# Altium schematic sheet Sheet1.SchDoc(Sheet1)
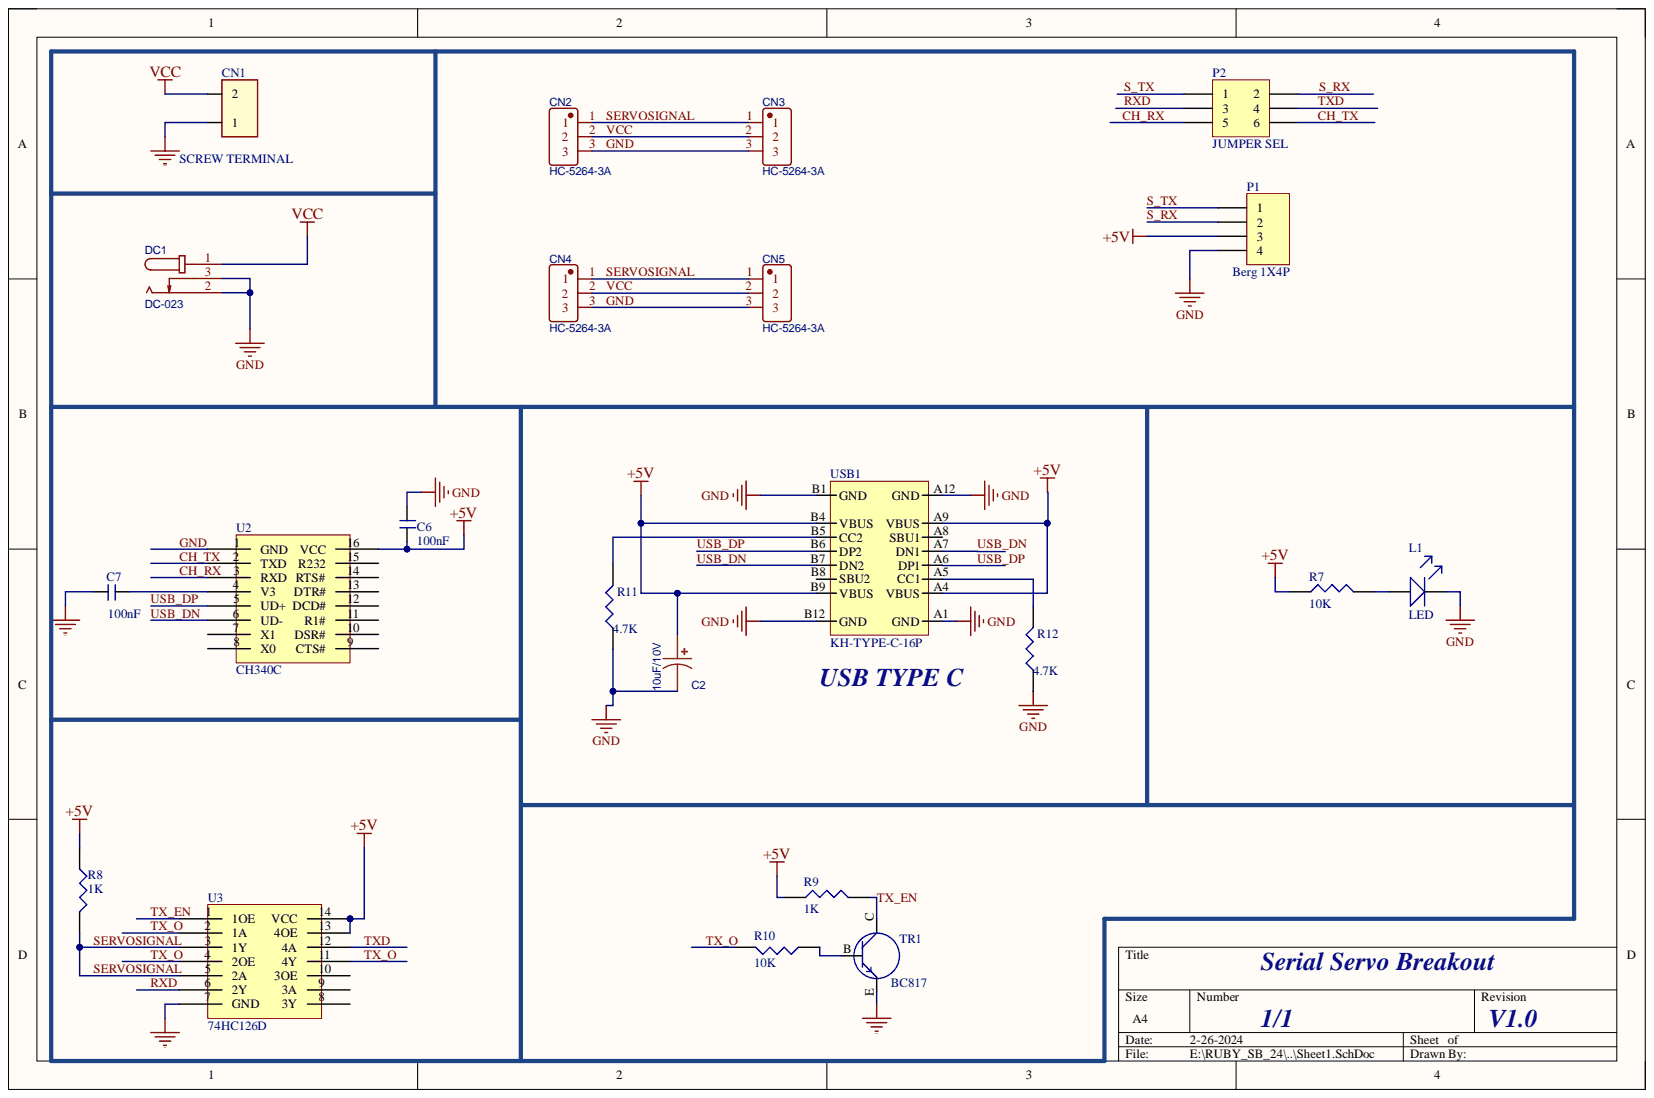
[diagram p0: Sheet1.SchDoc]

## Parts
C2  10uF/10V  [Tantlum_A_3216]
C6  100nF  [C_0603]
C7  100nF  [C_0603]
CN1  SCREW TERMINAL  [TERMINAL 2P_5mm]
CN2  HC-5264-3A  [1X3P P-2.5MM SERVO]
CN3  HC-5264-3A  [1X3P P-2.5MM SERVO]
CN4  HC-5264-3A  [1X3P P-2.5MM SERVO]
CN5  HC-5264-3A  [1X3P P-2.5MM SERVO]
DC1  DC-023  [DC-JACK_DC023]
L1  LED  [LED_0603]
P1  Berg 1X4P  [4P_TH_2.54MM]
P2  JUMPER SEL  [3X2P BERG]
R7  10K  [R_0603]
R8  1K  [0603]
R9  1K  [R_0603]
R10  10K  [R_0603]
R11  4.7K  [R_0603]
R12  4.7K  [R_0603]
TR1  BC817  [SOT23]
U2  CH340C  [SOIC_16]
U3  74HC126D  [SOIC-14]
USB1  KH-TYPE-C-16P  [USB TYPE _C]

## Nets
+5V: C2.1 C6.1 P1.3 R7.1 R8.1 R9.1 U2.16(VCC) U3.13(4OE) U3.14(VCC) USB1.A4(VBUS) USB1.A9(VBUS) USB1.B4(VBUS) USB1.B9(VBUS)
CH_RX: P2.5 U2.3(RXD)
CH_TX: P2.6 U2.2(TXD)
GND: C2.2 C6.2 C7.1 CN1.1 CN2.3 CN3.3 CN4.3 CN5.3 DC1.2 DC1.3 L1.K P1.4 R11.1 R12.1 TR1.E U2.1(GND) U3.7(GND) USB1.A1(GND) USB1.A12(GND) USB1.B1(GND) USB1.B12(GND)
RXD: P2.3 U3.6(2Y)
S_RX: P1.2 P2.2
S_TX: P1.1 P2.1
SERVOSIGNAL: CN2.1 CN3.1 CN4.1 CN5.1 R8.2 U3.3(1Y) U3.5(2A)
TX_EN: R9.2 TR1.C U3.1(1OE)
TX_O: R10.2 U3.2(1A) U3.4(2OE) U3.11(4Y)
TXD: P2.4 U3.12(4A)
USB_DN: U2.6(UD-) USB1.A7(DN1) USB1.B7(DN2)
USB_DP: U2.5(UD+) USB1.A6(DP1) USB1.B6(DP2)
VCC: CN1.2 CN2.2 CN3.2 CN4.2 CN5.2 DC1.1
NetC7_2: C7.2 U2.4(V3)
NetL1_A: L1.A R7.2
NetR10_1: R10.1 TR1.B
NetR11_2: R11.2 USB1.B5(CC2)
NetR12_2: R12.2 USB1.A5(CC1)

Not connected: U2 7(X1) 8(X0) 9(CTS#) 10(DSR#) 11(R1#) 12(DCD#) 13(DTR#) 14(RTS#) 15(R232); U3 8(3Y) 9(3A) 10(3OE); USB1 A8(SBU1) B8(SBU2)

## Library data of the parts
C2 = 270uF: BOM_Manufacturer: rubycon(红宝石); BOM_Manufacturer Part: 100ZL270MEFC12.5X35; Contributor: lcsc; nameAlias: Capacitance; BOM_JLCPCB Part Class: Extended Part; BOM_Supplier: LCSC; BOM_Supplier Part: C1598036; Component Kind: Standard; link: https://atta.szlcsc.com/upload/public/pdf/source/20210810/D32E969E718DCFB364F518B2A745C406.pdf; spicePre: C; spiceSymbolName: 100ZL270MEFC12.5X35; Library Name: MIX.SchLib
C6 C7 = CAP_NONPOLAR: Component Kind: Standard; Library Name: dxp_pasl_lib.IntLib
CN1 = CON2: Component Kind: Standard; Library Name: dxp_pasl_lib.IntLib
CN2-CN5 = HC-5264-3A: Manufacturer: HCTL(华灿天禄); Contributor: LCSC; Component Kind: Standard; JLCPCB Part Class: Extended Part; Manufacturer Part: HC-5264-3A; spicePre: C; spiceSymbolName: HC-5264-3A; Supplier: LCSC; Supplier Part: C2845815
DC1 = DC_JACK: BOM_Manufacturer: XKB Connectivity(中国星坤); Contributor: LCSC; BOM_JLCPCB Part Class: Extended Part; BOM_Manufacturer Part: DC-023; BOM_Supplier: LCSC; BOM_Supplier Part: C2689666; Component Kind: Standard; Description: DC-023; spicePre: D; spiceSymbolName: DC-023; Library Name: MIX.SchLib
P1 = Header 4: Header, 4-Pin; Component Kind: Standard; LatestRevisionDate: 17-Jul-2002; LatestRevisionNote: Re-released for DXP Platform.; Library Name: Miscellaneous Connectors.IntLib; Signal Integrity: Connector; Publisher: Altium Limited
P2 = Header 3X2: Header, 3-Pin, Dual row; Component Kind: Standard; LatestRevisionDate: 17-Jul-2002; LatestRevisionNote: Re-released for DXP Platform.; Library Name: Miscellaneous Connectors.IntLib; Signal Integrity: Connector; Publisher: Altium Limited
R7-R12 = RES: Component Kind: Standard; Library Name: dxp_pasl_lib.IntLib
TR1 = TRANSISTER_NPN: Component Kind: Standard; Library Name: dxp_pasl_lib.IntLib
USB1 = USB TYPE-C KH-TYPE-C-16P: 3A 1 Surface Mount 16 Female -40?~+85? Type-C SMD USB Connectors ROHS #  C709357; Component Kind: Standard; ComponentLink2Description: New link; ComponentLink2URL: https://lcsc.com/product-detail/USB-Connectors_Shenzhen-Kinghelm-Elec-KH-TYPE-C-16P_C709357.html; Supplier 1: LCSC; Supplier Part Number 1: C165948; Library Name: dxp_pasl_lib.IntLib
L1 = LED: Component Kind: Standard; Library Name: dxp_pasl_lib.IntLib
U2 = CH340C: Component Kind: Standard; Library Name: dxp_pasl_lib.IntLib
U3 = 74HC126D: Component Kind: Standard; Library Name: dxp_pasl_lib.IntLib
